# Altium schematic sheet Sheet3.SchDoc
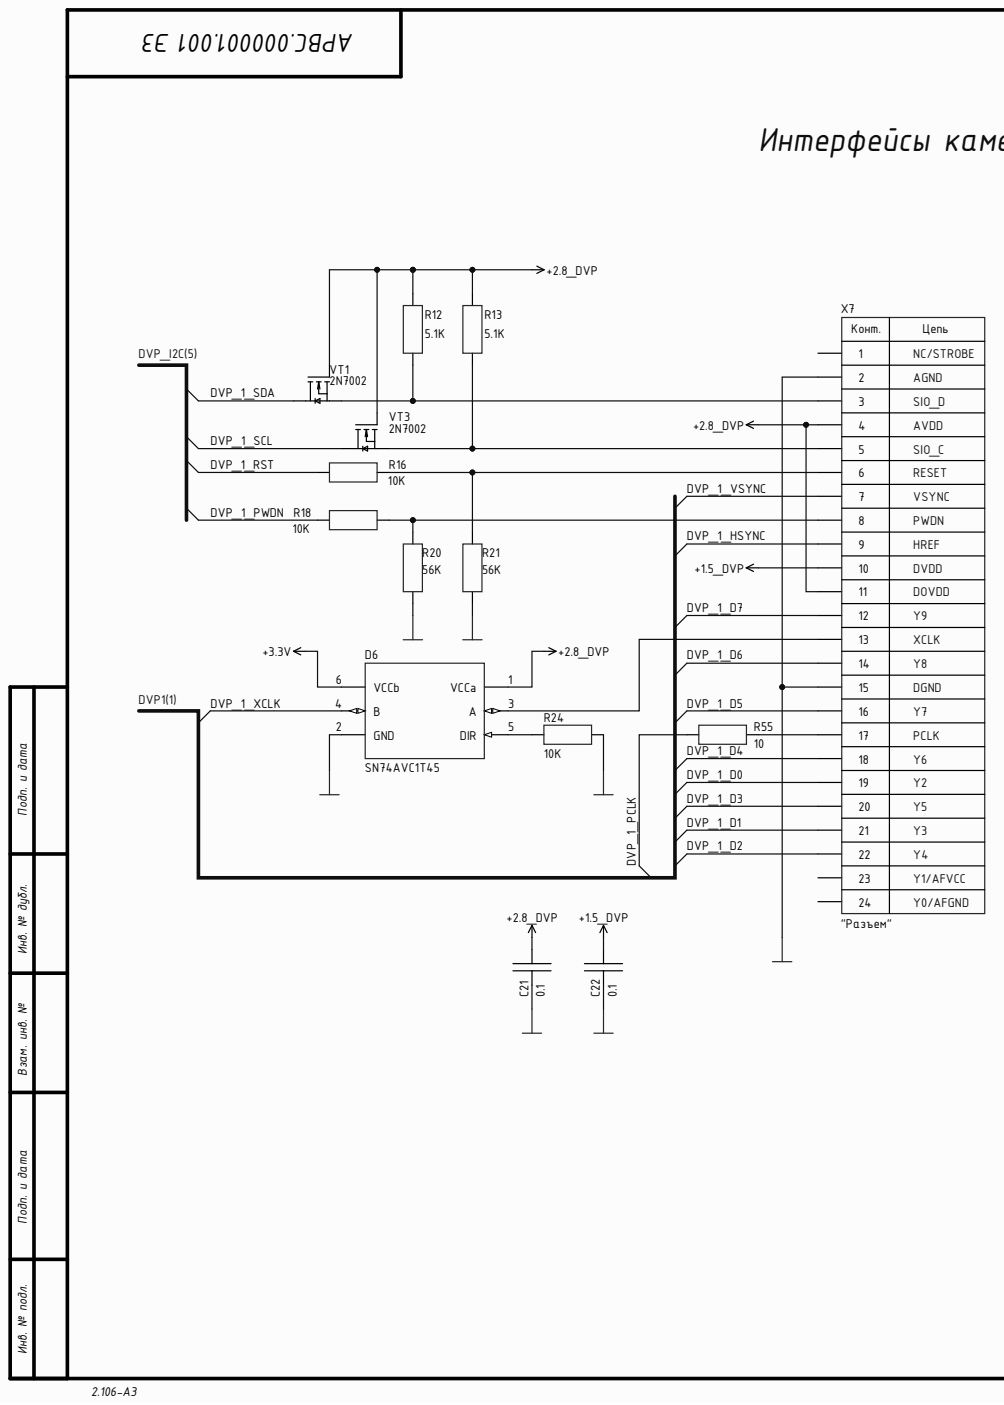
[diagram p0: Sheet3.SchDoc, left]
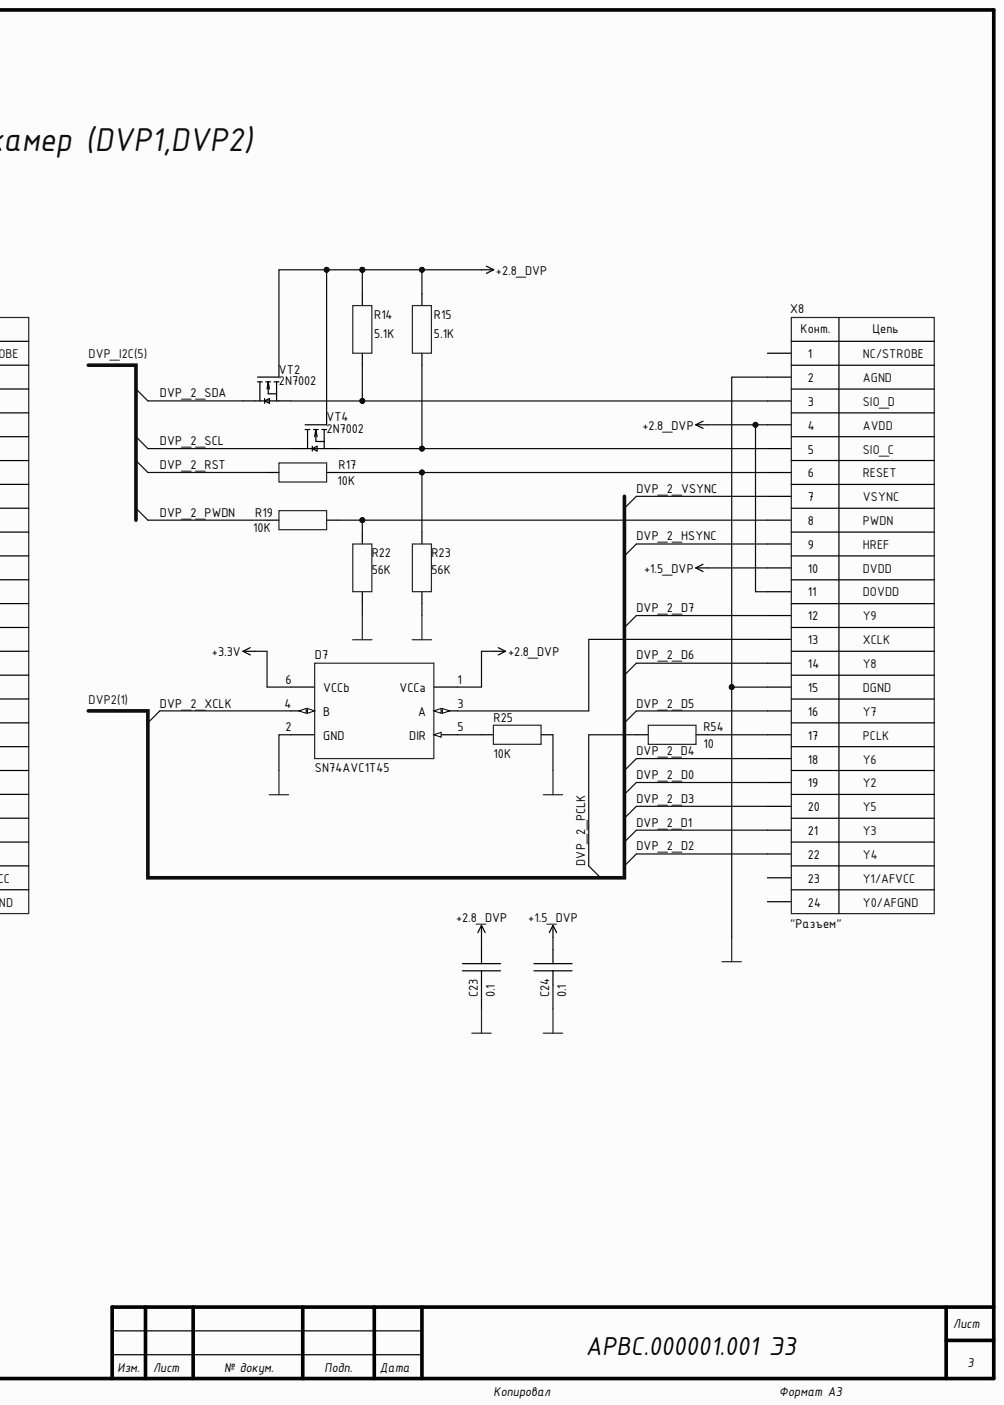
[diagram p1: Sheet3.SchDoc, right]

## Parts
C21  value 0.1  [CAP: 0603]  (hidden comment: Ceramic capacitor, 0.1, X7R, ±10%, 6.3V, 0603, -55...+125°C)
C22  value 0.1  [CAP: 0603]  (hidden comment: Ceramic capacitor, 0.1, X7R, ±10%, 6.3V, 0603, -55...+125°C)
C23  value 0.1  [CAP: 0603]  (hidden comment: Ceramic capacitor, 0.1, X7R, ±10%, 6.3V, 0603, -55...+125°C)
C24  value 0.1  [CAP: 0603]  (hidden comment: Ceramic capacitor, 0.1, X7R, ±10%, 6.3V, 0603, -55...+125°C)
D6  Level shifter, 500 Mbps, , 3...3.6V, -40...+85°C, 8-SOIC  [SOT23-6]  (value not drawn)
D7  Level shifter, 500 Mbps, , 3...3.6V, -40...+85°C, 8-SOIC  [SOT23-6]  (value not drawn)
R12  value 5.1K  [RES: 0603]  (hidden comment: Resistor film, 5.1K, ±5%, 0603, 0.1W, -55...+125°C)
R13  value 5.1K  [RES: 0603]  (hidden comment: Resistor film, 5.1K, ±5%, 0603, 0.1W, -55...+125°C)
R14  value 5.1K  [RES: 0603]  (hidden comment: Resistor film, 5.1K, ±5%, 0603, 0.1W, -55...+125°C)
R15  value 5.1K  [RES: 0603]  (hidden comment: Resistor film, 5.1K, ±5%, 0603, 0.1W, -55...+125°C)
R16  value 10K  [RES: 0603]  (hidden comment: Resistor film, 10K, ±5%, 0603, 0.1W, -55...+125°C)
R17  value 10K  [RES: 0603]  (hidden comment: Resistor film, 10K, ±5%, 0603, 0.1W, -55...+125°C)
R18  value 10K  [RES: 0603]  (hidden comment: Resistor film, 10K, ±5%, 0603, 0.1W, -55...+125°C)
R19  value 10K  [RES: 0603]  (hidden comment: Resistor film, 10K, ±5%, 0603, 0.1W, -55...+125°C)
R20  value 56K  [RES: 0603]  (hidden comment: Resistor film, 56K, ±5%, 0603, 0.1W, -55...+125°C)
R21  value 56K  [RES: 0603]  (hidden comment: Resistor film, 56K, ±5%, 0603, 0.1W, -55...+125°C)
R22  value 56K  [RES: 0603]  (hidden comment: Resistor film, 56K, ±5%, 0603, 0.1W, -55...+125°C)
R23  value 56K  [RES: 0603]  (hidden comment: Resistor film, 56K, ±5%, 0603, 0.1W, -55...+125°C)
R24  value 10K  [RES: 0603]  (hidden comment: Resistor film, 10K, ±5%, 0603, 0.1W, -55...+125°C)
R25  value 10K  [RES: 0603]  (hidden comment: Resistor film, 10K, ±5%, 0603, 0.1W, -55...+125°C)
R54  value 10  [RES: 0603]  (hidden comment: Resistor film, 10, , 0603, 0.1W, -55...+125°C)
R55  value 10  [RES: 0603]  (hidden comment: Resistor film, 10, , 0603, 0.1W, -55...+125°C)
VT1  PartNumber: 2N7002  [SOT23]  (hidden comment: MOSFET N-channel transistor, 60V, 0.115A, , 0.2W, 1.2Ohm, 20pF, SOT-23, -55...+150°C)
VT2  PartNumber: 2N7002  [SOT23]  (hidden comment: MOSFET N-channel transistor, 60V, 0.115A, , 0.2W, 1.2Ohm, 20pF, SOT-23, -55...+150°C)
VT3  PartNumber: 2N7002  [SOT23]  (hidden comment: MOSFET N-channel transistor, 60V, 0.115A, , 0.2W, 1.2Ohm, 20pF, SOT-23, -55...+150°C)
VT4  PartNumber: 2N7002  [SOT23]  (hidden comment: MOSFET N-channel transistor, 60V, 0.115A, , 0.2W, 1.2Ohm, 20pF, SOT-23, -55...+150°C)
X7  CommentText: "Разъем"  [CON: FH12F-24S-0.5SH (FPC-24)]  (hidden comment: FPC/FFC, 40pin, 0.5mm)
X8  CommentText: "Разъем"  [CON: FH12F-24S-0.5SH (FPC-24)]  (hidden comment: FPC/FFC, 40pin, 0.5mm)

## Nets
+1.5_DVP: C22.2 C24.2 X7.10 X8.10
+2.8_DVP: C21.2 C23.2 D6.1(VCCa) D7.1(VCCa) R12.2 R13.2 R14.2 R15.2 VT1.1 VT2.1 VT3.1 VT4.1 X7.4 X7.11 X8.4 X8.11
+3.3V: D6.6(VCCb) D7.6(VCCb)
GND: C21.1 C22.1 C23.1 C24.1 D6.2(GND) D7.2(GND) R20.1 R21.1 R22.1 R23.1 R24.2 R25.2 X7.2 X7.15 X8.2 X8.15
DVP_1_D0: X7.19
DVP_1_D1: X7.21
DVP_1_D2: X7.22
DVP_1_D3: X7.20
DVP_1_D4: X7.18
DVP_1_D5: X7.16
DVP_1_D6: X7.14
DVP_1_D7: X7.12
DVP_1_HSYNC: X7.9
DVP_1_PCLK: R55.1
DVP_1_PWDN: R18.2
DVP_1_RST: R16.2
DVP_1_SCL: VT3.3
DVP_1_SDA: VT1.3
DVP_1_VSYNC: X7.7
DVP_1_XCLK: D6.4(B)
DVP_2_D0: X8.19
DVP_2_D1: X8.21
DVP_2_D2: X8.22
DVP_2_D3: X8.20
DVP_2_D4: X8.18
DVP_2_D5: X8.16
DVP_2_D6: X8.14
DVP_2_D7: X8.12
DVP_2_HSYNC: X8.9
DVP_2_PCLK: R54.1
DVP_2_PWDN: R19.2
DVP_2_RST: R17.2
DVP_2_SCL: VT4.3
DVP_2_SDA: VT2.3
DVP_2_VSYNC: X8.7
DVP_2_XCLK: D7.4(B)
NetD6_3: D6.3(A) X7.13
NetD6_5: D6.5(DIR) R24.1
NetD7_3: D7.3(A) X8.13
NetD7_5: D7.5(DIR) R25.1
NetR12_1: R12.1 VT1.2 X7.3
NetR13_1: R13.1 VT3.2 X7.5
NetR14_1: R14.1 VT2.2 X8.3
NetR15_1: R15.1 VT4.2 X8.5
NetR16_1: R16.1 R21.2 X7.6
NetR17_1: R17.1 R23.2 X8.6
NetR18_1: R18.1 R20.2 X7.8
NetR19_1: R19.1 R22.2 X8.8
NetR54_2: R54.2 X8.17
NetR55_2: R55.2 X7.17

Not connected: X7 1 23 24; X8 1 23 24

## Library data of the parts
C21-C24 = Ceramic_0603: Manufacturer: Yageo; PartNumber: CC0603KRX7R7BB104; Component Kind: Standard; HelpURL: https://www.digikey.com/product-detail/en/yageo/CC0603KRX7R7BB104/311-1088-1-ND/302998; Library Name: Capacitor.IntLib; Package: 0603; Temperature: -55...+125°C; Tolerance: ±10%; TypeCapacitor: Ceramic capacitor; TypeDielectric: X7R; ValueManufacturerPartNumber: Ceramic capacitor, 0.1, X7R, ±10%, 6.3V, 0603, -55...+125°C; Voltage: 6.3V
D6 D7 = SN74AVC1T45: Level shifter; Manufacturer: Texas Instruments; Component Kind: Standard; ComponentType: Level shifter; DataRate: 500 Mbps; HelpURL: https://www.digikey.com/product-detail/en/texas-instruments/DS90LV028ATLD-NOPB/DS90LV028ATLD-NOPBCT-ND/562328; Library Name: IC. Interface.IntLib; Package: 8-SOIC; PartNumber: SN74AVC1T45; Supply: 3...3.6V; Temperature: -40...+85°C; ValueManufacturerPartNumber: Level shifter, 500 Mbps, , 3...3.6V, -40...+85°C, 8-SOIC
R12-R25 R54 R55 = Resistor_0603: Manufacturer: Yageo; PartNumber: RC0603JR-07100RL; Component Kind: Standard; HelpURL: https://www.digikey.com/product-detail/en/yageo/RC0603JR-07100RL/311-100GRCT-ND/729644; Library Name: Resistor.IntLib; Package: 0603; Power: 0.1W; Temperature: -55...+125°C; TypeResistor: Resistor film
  R12-R15: Tolerance: ±5%; ValueManufacturerPartNumber: Resistor film, 5.1K, ±5%, 0603, 0.1W, -55...+125°C
  R16-R19 R24 R25: Tolerance: ±5%; ValueManufacturerPartNumber: Resistor film, 10K, ±5%, 0603, 0.1W, -55...+125°C
  R20-R23: Tolerance: ±5%; ValueManufacturerPartNumber: Resistor film, 56K, ±5%, 0603, 0.1W, -55...+125°C
  R54 R55: ValueManufacturerPartNumber: Resistor film, 10, , 0603, 0.1W, -55...+125°C
VT1-VT4 = MOSFET_N_132: Manufacturer: FiarChild; Component Kind: Standard; CurrentDS: 0.115A; HelpURL: https://www.chipdip.ru/product/2n7002-jcet; InputCapacitor: 20pF; Library Name: Transistor.IntLib; MaxPower: 0.2W; Package: SOT-23; RDS: 1.2Ohm; Temperature: -55...+150°C; TypeTransistor: MOSFET N-channel transistor; ValueManufacturerPartNumber: MOSFET N-channel transistor, 60V, 0.115A, , 0.2W, 1.2Ohm, 20pF, SOT-23, -55...+150°C; VoltageDS: 60V
X7 X8 = Connector_FPC_24_0.5_2: Manufacturer: Molex; PartNumber: 68714014522; Component Kind: Standard; HelpURL: https://www.chipdip.ru/product/pls-20; Library Name: Connector.IntLib; Multichannel: 40pin; Pitch: 0.5mm; TypeConnector: FPC/FFC; ValueManufacturerPartNumber: FPC/FFC, 40pin, 0.5mm
